# Altium schematic sheet audio.SchDoc
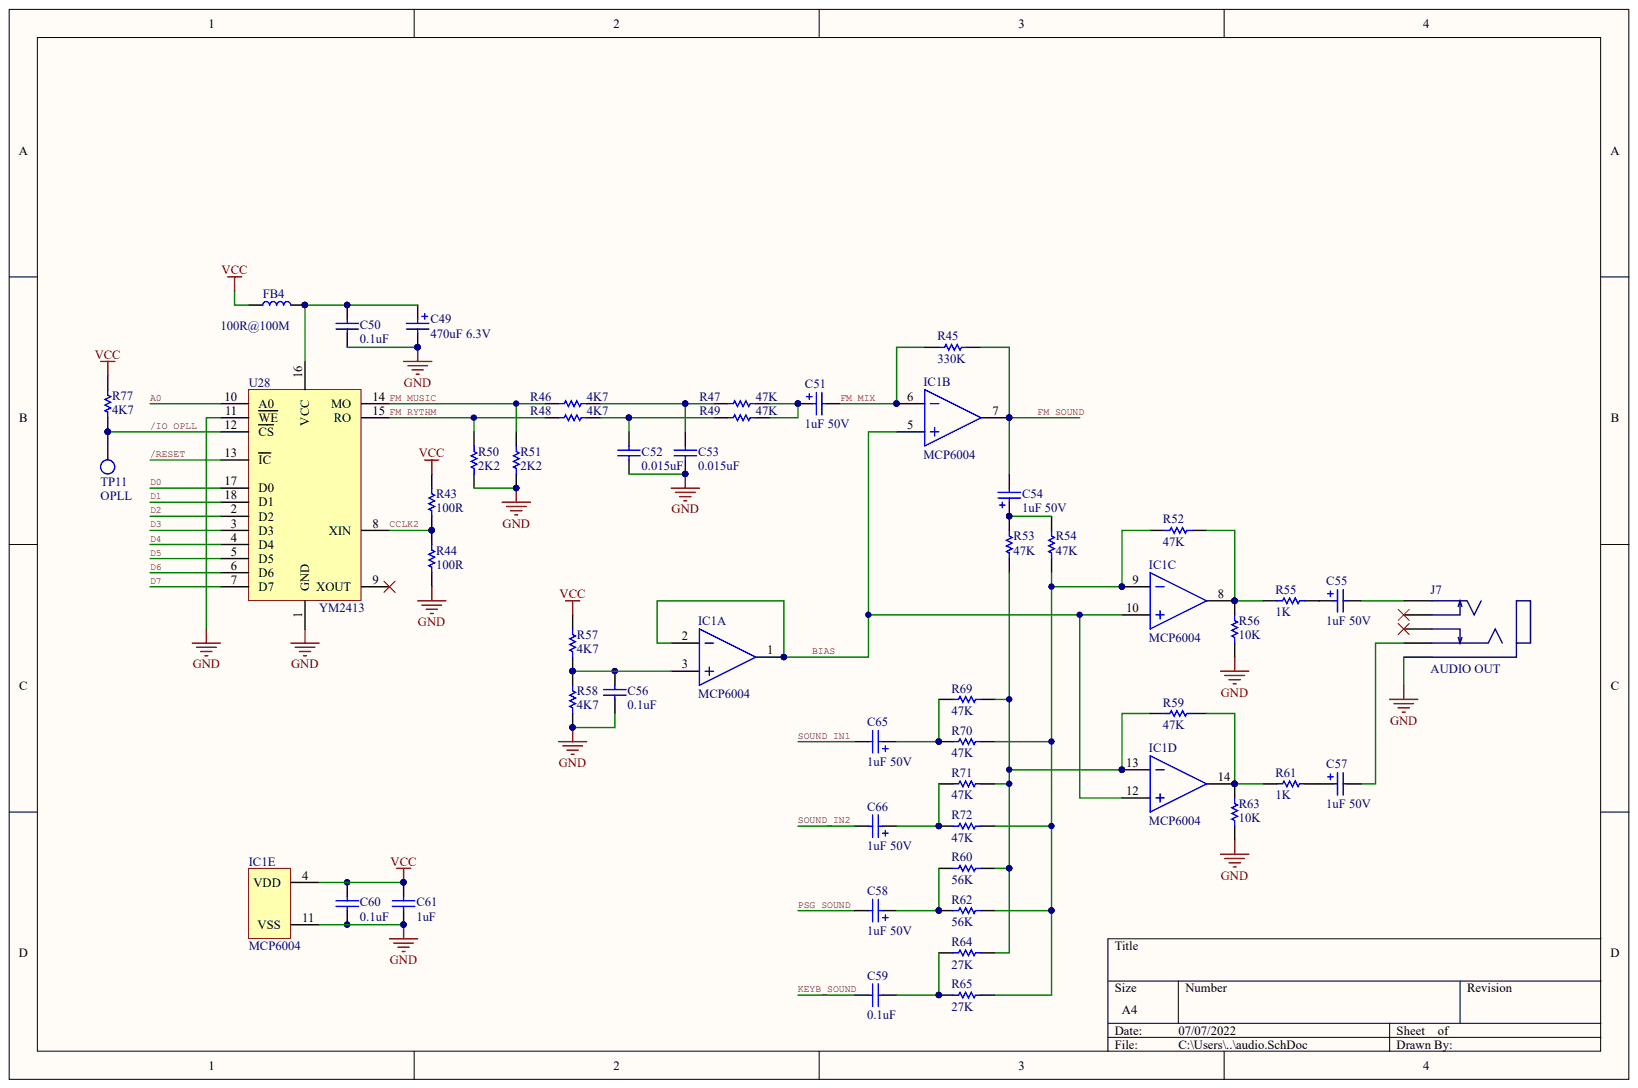
[diagram p0: audio.SchDoc]

## Parts
C49  470uF 6.3V
C50  0.1uF
C51  1uF 50V
C52  0.015uF
C53  0.015uF
C54  1uF 50V
C55  1uF 50V
C56  0.1uF
C57  1uF 50V
C58  1uF 50V
C59  0.1uF
C60  0.1uF
C61  1uF
C65  1uF 50V
C66  1uF 50V
FB4  100R@100M
IC1  MCP6004  (parts A,B,C,D,E)
J7  AUDIO OUT
R43  100R
R44  100R
R45  330K
R46  4K7
R47  47K
R48  4K7
R49  47K
R50  2K2
R51  2K2
R52  47K
R53  47K
R54  47K
R55  1K
R56  10K
R57  4K7
R58  4K7
R59  47K
R60  56K
R61  1K
R62  56K
R63  10K
R64  27K
R65  27K
R69  47K
R70  47K
R71  47K
R72  47K
R77  4K7
TP11  OPLL
U28  YM2413

## Nets
GND: C49.2 C50.1 C52.1 C53.1 C56.1 C60.1 C61.1 IC1.11(VSS) J7.SLEEVE R44.2 R50.2 R51.2 R56.2 R58.2 R63.2 U28.1(GND) U28.11(WE)
VCC: C60.2 C61.2 FB4.1 IC1.4(VDD) R43.1 R57.1 R77.1
/IO_OPLL: R77.2 TP11.1 U28.12(CS)
/RESET: U28.13(IC)
A0: U28.10(A0)
BIAS: IC1.1 IC1.2 IC1.5 IC1.10 IC1.12
CCLK2: R43.2 R44.1 U28.8(XIN)
D0: U28.17(D0)
D1: U28.18(D1)
D2: U28.2(D2)
D3: U28.3(D3)
D4: U28.4(D4)
D5: U28.5(D5)
D6: U28.6(D6)
D7: U28.7(D7)
FM_MIX: C51.2 IC1.6 R45.1
FM_MUSIC: R46.1 R51.1 U28.14(MO)
FM_RYTHM: R48.1 R50.1 U28.15(RO)
FM_SOUND: C54.2 IC1.7 R45.2
KEYB_SOUND: C59.2
PSG_SOUND: C58.2
SOUND_IN1: C65.2
SOUND_IN2: C66.2
NetC49_1: C49.1 C50.2 FB4.2 U28.16(VCC)
NetC51_1: C51.1 R47.2 R49.2
NetC52_2: C52.2 R48.2 R49.1
NetC53_2: C53.2 R46.2 R47.1
NetC54_1: C54.1 R53.2 R54.2
NetC55_1: C55.1 R55.2
NetC55_2: C55.2 J7.TIP
NetC56_2: C56.2 IC1.3 R57.2 R58.1
NetC57_1: C57.1 R61.2
NetC57_2: C57.2 J7.RING
NetC58_1: C58.1 R60.2 R62.2
NetC59_1: C59.1 R64.2 R65.2
NetC65_1: C65.1 R69.2 R70.2
NetC66_1: C66.1 R71.2 R72.2
NetIC1_8: IC1.8 R52.2 R55.1 R56.1
NetIC1_9: IC1.9 R52.1 R54.1 R62.1 R65.1 R70.1 R72.1
NetIC1_13: IC1.13 R53.1 R59.1 R60.1 R64.1 R69.1 R71.1
NetIC1_14: IC1.14 R59.2 R61.1 R63.1

Not connected: J7 RING_SW TIP_SW; U28 9(XOUT)

## Library data of the parts
C49-C61 C65 C66 FB4 IC1 J7 R43-R65 R69-R72 R77 TP11 U28 = (no symbol name)
  C49: VALUE: 470uF 6.3V
  C50 C56 C59 C60: VALUE: 0.1uF
  C51 C54 C55 C57 C58 C65 C66: VALUE: 1uF 50V
  C52 C53: VALUE: 0.015uF
  C61: VALUE: 1uF
  FB4: VALUE: 100R@100M
  IC1: VALUE: MCP6004
  J7: PartNumber: AUDIO OUT
  R43 R44: Value: 100R
  R45: Value: 330K
  R46 R48 R57 R58 R77: Value: 4K7
  R47 R49 R52-R54 R59 R69-R72: Value: 47K
  R50 R51: Value: 2K2
  R55 R61: Value: 1K
  R56 R63: Value: 10K
  R60 R62: Value: 56K
  R64 R65: Value: 27K
  TP11: Value: OPLL
  U28: PartNumber: YM2413
